# Altium schematic sheet BTT_M157_Interface_V0.3.SchDoc(BTT_M157_Interface_V0.3)
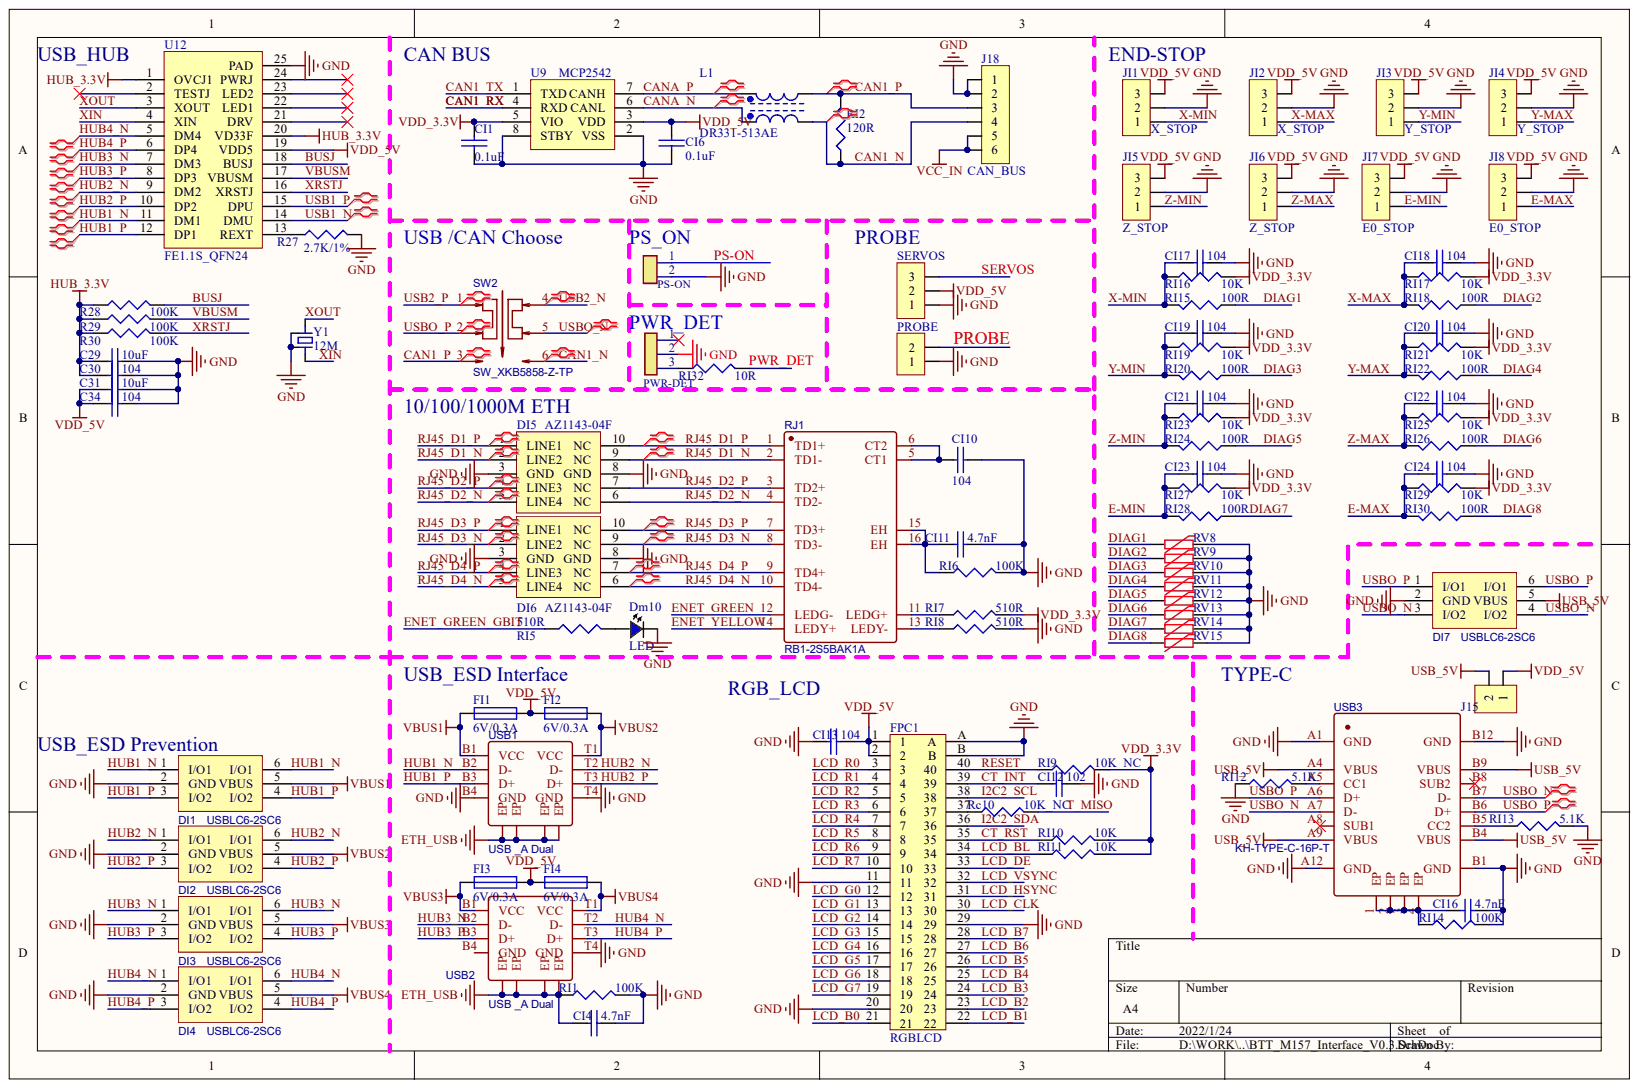
[diagram p0: BTT_M157_Interface_V0.3.SchDoc]

## Parts
C29  10uF  [0805C]
C30  104  [0603C]
C31  10uF  [0805C]
C34  104  [0603C]
CI1  0.1uF  [0603C]
CI4  4.7nF  [0603C]
CI6  0.1uF  [0603C]
CI10  104  [0603C]
CI11  4.7nF  [0603C]
CI12  102  [0603C]
CI13  104  [0603C]
CI16  value 4.7nF  [0603C]  (hidden comment: 4700PF)
CI17  104  [0603C]
CI18  104  [0603C]
CI19  104  [0603C]
CI20  104  [0603C]
CI21  104  [0603C]
CI22  104  [0603C]
CI23  104  [0603C]
CI24  104  [0603C]
DI1  USBLC6-2SC6  [SOT23-6]
DI2  USBLC6-2SC6  [SOT23-6]
DI3  USBLC6-2SC6  [SOT23-6]
DI4  USBLC6-2SC6  [SOT23-6]
DI5  AZ1143-04F  [DFN2510]
DI6  AZ1143-04F  [DFN2510]
DI7  USBLC6-2SC6  [SOT23-6]
Dm10  LED  [LED-0603-green]
FI1  6V/0.3A  [0603F]
FI2  6V/0.3A  [0603F]
FI3  6V/0.3A  [0603F]
FI4  6V/0.3A  [0603F]
FPC1  RGBLCD  [FPC_0.5MM_40P_LS]
J15  USB_5V  [SIP2-2.54-BLACK]
J18  2.54-6P-直针插座  [XH2.54-LI-6P]  (value not drawn)
JI1  X_MIN  [XH2.54-3P-直针插座]
JI2  X_MAX  [XH2.54-3P-直针插座]
JI3  Y_MIN  [XH2.54-3P-直针插座]
JI4  Y_MAX  [XH2.54-3P-直针插座]
JI5  Z_MIN  [XH2.54-3P-直针插座]
JI6  Z_MAX  [XH2.54-3P-直针插座]
JI7  E_MIN  [XH2.54-3P-直针插座]
JI8  E_MAX  [XH2.54-3P-直针插座]
L1  DR331-513AE  [FILTER_0805]
PROBE  XH2.54-2P-直针  [XH2.54-2P-直针插座]  (value not drawn)
PS-ON  XH2.54-2P-直针插座  [XH2.54-2P-直针插座]  (value not drawn)
PWR-DET  2.54-3P-直针插座  [XH2.54-3P-直针插座]  (value not drawn)
R27  2.7K/1%  [0603R]
R28  100K  [0603R]
R29  100K  [0603R]
R30  100K  [0603R]
Rc10  10K_NC  [0603R]
RI1  100K  [0603R]
RI2  120R  [0603R]
RI5  510R  [0603R]
RI6  100K  [0603R]
RI7  510R  [0603R]
RI8  510R  [0603R]
RI9  10K_NC  [0603R]
RI10  10K  [0603R]
RI11  10K  [0603R]
RI12  5.1K  [0603R]
RI13  5.1K  [0603R]
RI14  100K  [0603R]
RI15  100R  [0603R]
RI16  10K  [0603R]
RI17  10K  [0603R]
RI18  100R  [0603R]
RI19  10K  [0603R]
RI20  100R  [0603R]
RI21  10K  [0603R]
RI22  100R  [0603R]
RI23  10K  [0603R]
RI24  100R  [0603R]
RI25  10K  [0603R]
RI26  100R  [0603R]
RI27  10K  [0603R]
RI28  100R  [0603R]
RI29  10K  [0603R]
RI30  100R  [0603R]
RI32  10R  [0603R]
RJ1  RB1-2S5BAK1A  [RJ45_RB1-2S5BAK1A]
RV8  CG0603MLC-05E  [0603L]  (value not drawn)
RV9  CG0603MLC-05E  [0603L]  (value not drawn)
RV10  CG0603MLC-05E  [0603L]  (value not drawn)
RV11  CG0603MLC-05E  [0603L]  (value not drawn)
RV12  CG0603MLC-05E  [0603L]  (value not drawn)
RV13  CG0603MLC-05E  [0603L]  (value not drawn)
RV14  CG0603MLC-05E  [0603L]  (value not drawn)
RV15  CG0603MLC-05E  [0603L]  (value not drawn)
SERVOS  2.54-3P-直针插座  [XH2.54-3P-直针插座]  (value not drawn)
SW2  SW_XKB5858-Z-TP  [SW-SMD_6P]
U9  MCP2542  [SOP8]
U12  FE1.1S_QFN24  [QFN24_4X4]
USB1  USB _A Dual  [USB _A Dual]
USB2  USB _A Dual  [USB _A Dual]
USB3  KH-TYPE-C-16P-T  [USB_C_SMD_16P]
Y1  12M  [XTAL_3225]

## Nets
ETH_USB: CI4.2 RI1.1 USB1.CH1(EP) USB1.CH2(EP) USB1.CH3(EP) USB1.CH4(EP) USB2.CH1(EP) USB2.CH2(EP) USB2.CH3(EP) USB2.CH4(EP)
GND: C29.1 C30.1 C31.1 C34.1 CI1.2 CI4.1 CI6.2 CI10.2 CI11.2 CI12.1 CI13.2 CI16.2 CI17.1 CI18.1 CI19.1 CI20.1 CI21.1 CI22.1 CI23.1 CI24.1 DI1.2(GND) DI2.2(GND) DI3.2(GND) DI4.2(GND) DI5.3(GND) DI5.8(GND) DI6.3(GND) DI6.8(GND) DI7.2(GND) Dm10.K FPC1.11 FPC1.20 FPC1.29 FPC1.A FPC1.B J18.1 J18.2 JI1.2 JI2.2 JI3.2 JI4.2 JI5.2 JI6.2 JI7.2 JI8.2 PROBE.1 PS-ON.2 PWR-DET.2 R27.2 RI1.2 RI6.2 RI8.2 RI12.1 RI13.2 RI14.2 RV8.2 RV9.2 RV10.2 RV11.2 RV12.2 RV13.2 RV14.2 RV15.2 SERVOS.1 U9.2(VSS) U9.8(STBY) U12.25(PAD) USB1.B4(GND) USB1.T4(GND) USB2.T4(GND) USB3.A1(GND) USB3.A12(GND) USB3.B1(GND) USB3.B12(GND) Y1.2 Y1.4
HUB_3.3V: C29.2 C30.2 R28.1 R29.1 R30.1 U12.1(OVCJ1) U12.20(VD33F)
USB_5V: DI7.5(VBUS) J15.2 USB3.A4(VBUS) USB3.A9(VBUS) USB3.B4(VBUS) USB3.B9(VBUS)
VBUS1: DI1.5(VBUS) FI1.2 USB1.B1(VCC)
VBUS2: DI2.5(VBUS) FI2.2 USB1.T1(VCC)
VBUS3: DI3.5(VBUS) FI3.1 USB2.B1(VCC)
VBUS4: DI4.5(VBUS) FI4.2 USB2.T1(VCC)
VCC_IN: J18.5 J18.6
VDD_3.3V: CI1.1 RI7.2 RI9.2 RI10.2 RI11.2 RI16.2 RI17.2 RI19.2 RI21.2 RI23.2 RI25.2 RI27.2 RI29.2 U9.5(VIO)
VDD_5V: C31.2 C34.2 CI6.1 CI13.1 FI1.1 FI2.1 FI3.2 FI4.1 FPC1.1 FPC1.2 J15.1 JI1.3 JI2.3 JI3.3 JI4.3 JI5.3 JI6.3 JI7.3 JI8.3 SERVOS.2 U9.3(VDD) U12.19(VDD5)
BUSJ: R28.2 U12.18(BUSJ)
CAN1_N: J18.4 L1.3 RI2.1 SW2.6
CAN1_P: J18.3 L1.4 RI2.2 SW2.3
CAN1_RX: U9.4(RXD)
CAN1_TX: U9.1(TXD)
CANA_N: L1.2 U9.6(CANL)
CANA_P: L1.1 U9.7(CANH)
CT_INT: CI12.2 FPC1.39
CT_RST: FPC1.35 RI10.1
DIAG1: RI15.1 RV8.1
DIAG2: RI18.1 RV9.1
DIAG3: RI20.1 RV10.1
DIAG4: RI22.1 RV11.1
DIAG5: RI24.1 RV12.1
DIAG6: RI26.1 RV13.1
DIAG7: RI28.1 RV14.1
DIAG8: RI30.1 RV15.1
E-MAX: CI24.2 JI8.1 RI29.1 RI30.2
E-MIN: CI23.2 JI7.1 RI27.1 RI28.2
ENET_GREEN: RJ1.12(LEDG-)
ENET_GREEN_GBIT: RI5.1
ENET_YELLOW: RJ1.14(LEDY+)
HUB1_N: DI1.1(I/O1) DI1.6(I/O1) U12.11(DM1) USB1.B2(D-)
HUB1_P: DI1.3(I/O2) DI1.4(I/O2) U12.12(DP1) USB1.B3(D+)
HUB2_N: DI2.1(I/O1) DI2.6(I/O1) U12.9(DM2) USB1.T2(D-)
HUB2_P: DI2.3(I/O2) DI2.4(I/O2) U12.10(DP2) USB1.T3(D+)
HUB3_N: DI3.1(I/O1) DI3.6(I/O1) U12.7(DM3) USB2.B2(D-)
HUB3_P: DI3.3(I/O2) DI3.4(I/O2) U12.8(DP3) USB2.B3(D+)
HUB4_N: DI4.1(I/O1) DI4.6(I/O1) U12.5(DM4) USB2.T2(D-)
HUB4_P: DI4.3(I/O2) DI4.4(I/O2) U12.6(DP4) USB2.T3(D+)
I2C2_SCL: FPC1.38
I2C2_SDA: FPC1.36
LCD_B0: FPC1.21
LCD_B1: FPC1.22
LCD_B2: FPC1.23
LCD_B3: FPC1.24
LCD_B4: FPC1.25
LCD_B5: FPC1.26
LCD_B6: FPC1.27
LCD_B7: FPC1.28
LCD_BL: FPC1.34 RI11.1
LCD_CLK: FPC1.30
LCD_DE: FPC1.33
LCD_G0: FPC1.12
LCD_G1: FPC1.13
LCD_G2: FPC1.14
LCD_G3: FPC1.15
LCD_G4: FPC1.16
LCD_G5: FPC1.17
LCD_G6: FPC1.18
LCD_G7: FPC1.19
LCD_HSYNC: FPC1.31
LCD_R0: FPC1.3
LCD_R1: FPC1.4
LCD_R2: FPC1.5
LCD_R3: FPC1.6
LCD_R4: FPC1.7
LCD_R5: FPC1.8
LCD_R6: FPC1.9
LCD_R7: FPC1.10
LCD_VSYNC: FPC1.32
PROBE: PROBE.2
PS-ON: PS-ON.1
PWR_DET: RI32.2
RESET: FPC1.40 RI9.1
RJ45_D1_N: DI5.2(LINE2) DI5.9(NC) RJ1.2(TD1-)
RJ45_D1_P: DI5.1(LINE1) DI5.10(NC) RJ1.1(TD1+)
RJ45_D2_N: DI5.5(LINE4) DI5.6(NC) RJ1.4(TD2-)
RJ45_D2_P: DI5.4(LINE3) DI5.7(NC) RJ1.3(TD2+)
RJ45_D3_N: DI6.2(LINE2) DI6.9(NC) RJ1.8(TD3-)
RJ45_D3_P: DI6.1(LINE1) DI6.10(NC) RJ1.7(TD3+)
RJ45_D4_N: DI6.5(LINE4) DI6.6(NC) RJ1.10(TD4-)
RJ45_D4_P: DI6.4(LINE3) DI6.7(NC) RJ1.9(TD4+)
SERVOS: SERVOS.3
T_MISO: Rc10.2
USB1_N: U12.14(DMU)
USB1_P: U12.15(DPU)
USB2_N: SW2.4
USB2_P: SW2.1
USBO_N: DI7.3(I/O2) DI7.4(I/O2) SW2.5 USB3.A7(D-) USB3.B7(D-)
USBO_P: DI7.1(I/O1) DI7.6(I/O1) SW2.2 USB3.A6(D+) USB3.B6(D+)
VBUSM: R29.2 U12.17(VBUSM)
X-MAX: CI18.2 JI2.1 RI17.1 RI18.2
X-MIN: CI17.2 JI1.1 RI15.2 RI16.1
XIN: U12.4(XIN) Y1.1
XOUT: U12.3(XOUT) Y1.3
XRSTJ: R30.2 U12.16(XRSTJ)
Y-MAX: CI20.2 JI4.1 RI21.1 RI22.2
Y-MIN: CI19.2 JI3.1 RI19.1 RI20.2
Z-MAX: CI22.2 JI6.1 RI25.1 RI26.2
Z-MIN: CI21.2 JI5.1 RI23.1 RI24.2
NetCI10_1: CI10.1 RJ1.5(CT1) RJ1.6(CT2)
NetCI11_1: CI11.1 RI6.1 RJ1.15(EH) RJ1.16(EH)
NetCI16_1: CI16.1 RI14.1 USB3.1(EP) USB3.2(EP) USB3.3(EP) USB3.4(EP)
NetDm10_A: Dm10.A RI5.2
NetFPC1_37: FPC1.37 Rc10.1
NetPWR-DET_3: PWR-DET.3 RI32.1
NetR27_1: R27.1 U12.13(REXT)
NetRI7_1: RI7.1 RJ1.11(LEDG+)
NetRI8_1: RI8.1 RJ1.13(LEDY-)
NetRI12_2: RI12.2 USB3.A5(CC1)
NetRI13_1: RI13.1 USB3.B5(CC2)

Not connected: PWR-DET 1; U12 2(TESTJ) 21(DRV) 22(LED1) 23(LED2) 24(PWRJ); USB2 B4(GND); USB3 A8(SUB1) B8(SUB2)

## Library data of the parts
C29-C31 C34 = CAP: Capacitor; Component Kind: Standard; Library Name: My Useful Discrete Devices.SchLib
CI1 CI4 CI6 CI10-CI13 CI17-CI24 = CAP: Component Kind: Standard
  CI1 CI6 CI11: Library Name: 常用库.SCHLIB
  CI4 CI10 CI12 CI13 CI17-CI24: Library Name: liroqi.SchLib
CI16 = Cap: Capacitor; Component Kind: Standard; LatestRevisionDate: 17-Jul-2002; LatestRevisionNote: Re-released for DXP Platform.; PackageDescription: Radial Cap, Thru-Hole; 2 Leads; 0.3 in Pin Spacing; PackageReference: RAD-0.3; Published: 8-Jun-2000; Signal Integrity: Cap; Simulation: CAP; Library Name: 常用元器件.SchLib; Publisher: Altium Limited
DI1-DI4 DI7 = USBLC6-2SC6: BOM_Manufacturer: STMicroelectronics; BOM_Manufacturer Part: USBLC6-2P6; SMT Type: Extend; BOM_LCSC Assembly: Yes; BOM_Supplier: LCSC; BOM_Supplier Part: C15999; Component Kind: Standard; image: //image.lceda.cn/szlcsc/C15999.jpg; spicePre: D; spiceSymbolName: USBLC6-2P6; Library Name: My Useful Discrete Devices.SchLib
DI5 DI6 = AZ1143-04F: ESD保护二极管阵列; Component Kind: Standard; Library Name: My Useful Discrete Devices.SchLib
Dm10 = LED_1: Component Kind: Standard; Library Name: My Useful Discrete Devices.SchLib
FI1-FI4 = FUSE1: Fuse; Component Kind: Standard; Library Name: My Useful Discrete Devices.SchLib
FPC1 = FPC-40: FPC-40P-0.5mm; Component Kind: Standard; Library Name: My Useful Discrete Devices.SchLib
J15 PROBE = CON2: Connector; Component Kind: Standard; Library Name: My Useful Discrete Devices.SchLib
J18 = CON6: Connector; : CAN_BUS; Component Kind: Standard; Library Name: My Useful Discrete Devices.SchLib
JI1-JI8 SERVOS = CON3: Connector; Component Kind: Standard; Library Name: My Useful Discrete Devices.SchLib
  JI1 JI2: : X_STOP
  JI3 JI4: : Y_STOP
  JI5 JI6: : Z_STOP
  JI7 JI8: : E0_STOP
PS-ON = SIP2: Component Kind: Standard; Library Name: liroqi.SchLib
PWR-DET = SIP3: Component Kind: Standard; Library Name: liroqi.SchLib
R27-R30 Rc10 RI1 RI2 RI5-RI30 RI32 = RES1: Component Kind: Standard
  R27-R30 RI2 RI5 RI7 RI8 RI15-RI30 RI32: Library Name: My Useful Discrete Devices.SchLib
  Rc10 RI1 RI6 RI9-RI14: Library Name: 常用库.SCHLIB
RJ1 = RB1-2S5BAK1A: 1000M RJ45接口; BOM_Manufacturer: UDE Corp; Contributor: LCSC; BOM_JLCPCB Part Class: Extended Part; BOM_Manufacturer Part: RB1-2S5BAK1A; BOM_Supplier: LCSC; BOM_Supplier Part: C363232; Component Kind: Standard; spicePre: R; spiceSymbolName: RB1-2S5BAK1A; Library Name: My Useful Discrete Devices.SchLib
RV8-RV15 = CG0603MLC-05E: VAR 0603 5V; Manufacturer: YAGEO; EE_SPEC: 5VDC; Tolerance: NA; Component Kind: Standard; DISTRIBUTOR: Digi-Key; DISTRIBUTOR #: CG0603MLC-05ETR-ND; Library Name: 3Delight.SCHLIB; MANUFACTURER #: CG0603MLC-05E; PART: 5VDC; Part Description: VARISTOR 0603; Signal Integrity: Model Name; Simulation: Model Name; VALUE: 5V
SW2 = SW_XKB5858-Z-TP: 按压式双刀双掷波动开关; BOM_Manufacturer: XKB Connectivity; Contributor: LCSC; BOM_JLCPCB Part Class: Extended Part; BOM_Manufacturer Part: XKB5858-Z-TP; BOM_Supplier: LCSC; BOM_Supplier Part: C840833; Component Kind: Standard; spicePre: S; spiceSymbolName: XKB5858-Z-TP_C840833; Library Name: My Useful Discrete Devices.SchLib
U12 = FE1.1S: USB HUB芯片; Component Kind: Standard; Library Name: My Useful IC.SchLib
USB1 USB2 = USBA: 双路USB A型接口; BOM_Manufacturer: Shenzhen Kinghelm Elec; BOM_Manufacturer Part: KH-2AF90DIP-1715; Contributor: LCSC; BOM_JLCPCB Part Class: Extended Part; BOM_Supplier: LCSC; BOM_Supplier Part: C709360; Component Kind: Standard; spicePre: U; spiceSymbolName: KH-2AF90DIP-1715; Library Name: My Useful Discrete Devices.SchLib
USB3 = KH-TYPE-C-16P-T: TYPEC接口; BOM_Manufacturer: Shenzhen Kinghelm Elec; Contributor: LCSC; BOM_JLCPCB Part Class: Extended Part; BOM_Manufacturer Part: KH-TYPE-C-16P-T; BOM_Supplier: LCSC; BOM_Supplier Part: C709358; Component Kind: Standard; spicePre: U; spiceSymbolName: KH-TYPE-C-16P-T; Library Name: 常用库.SCHLIB
Y1 = CRYSTAL_SMD: 贴片四脚晶振; Component Kind: Standard; Library Name: My Useful Discrete Devices.SchLib
L1 = DR331-513AE: Component Kind: Standard; Library Name: My Useful Discrete Devices.SchLib
U9 = MCP2542: Component Kind: Standard; Library Name: My Useful IC.SchLib
